# Altium schematic sheet Circuit Schematics.SchDoc("Circuit Schematics")
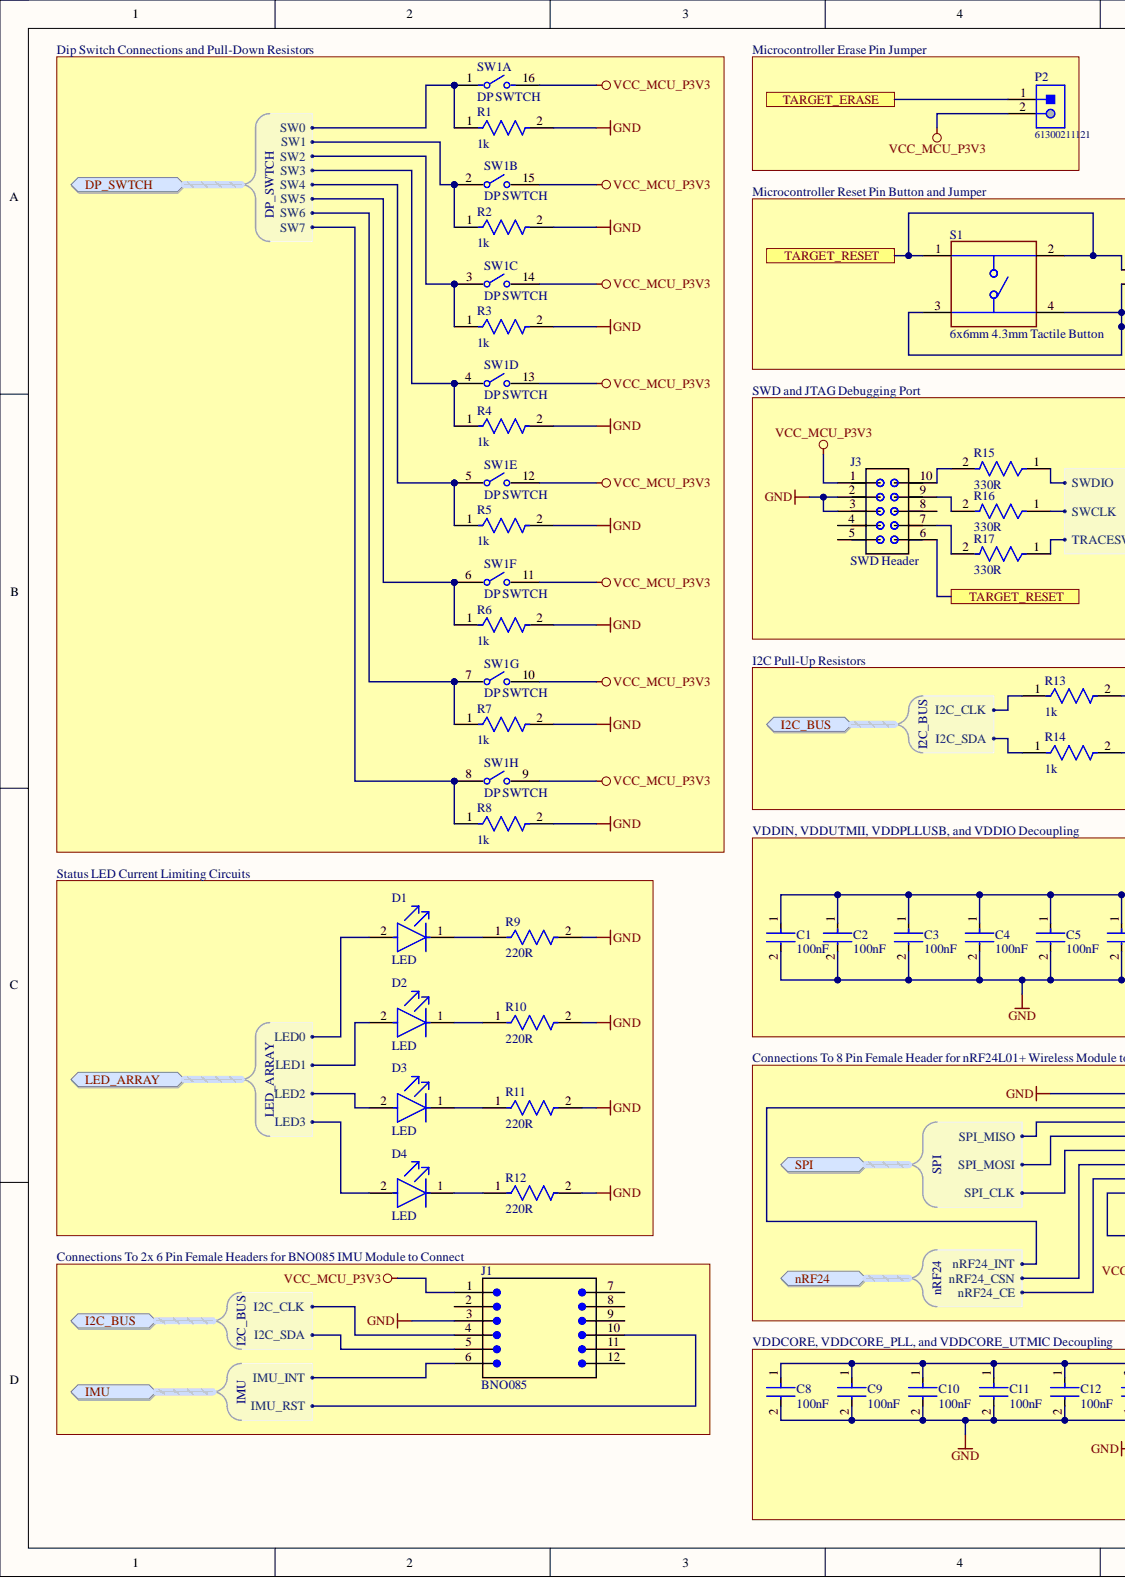
[diagram p0: Circuit Schematics.SchDoc, left]
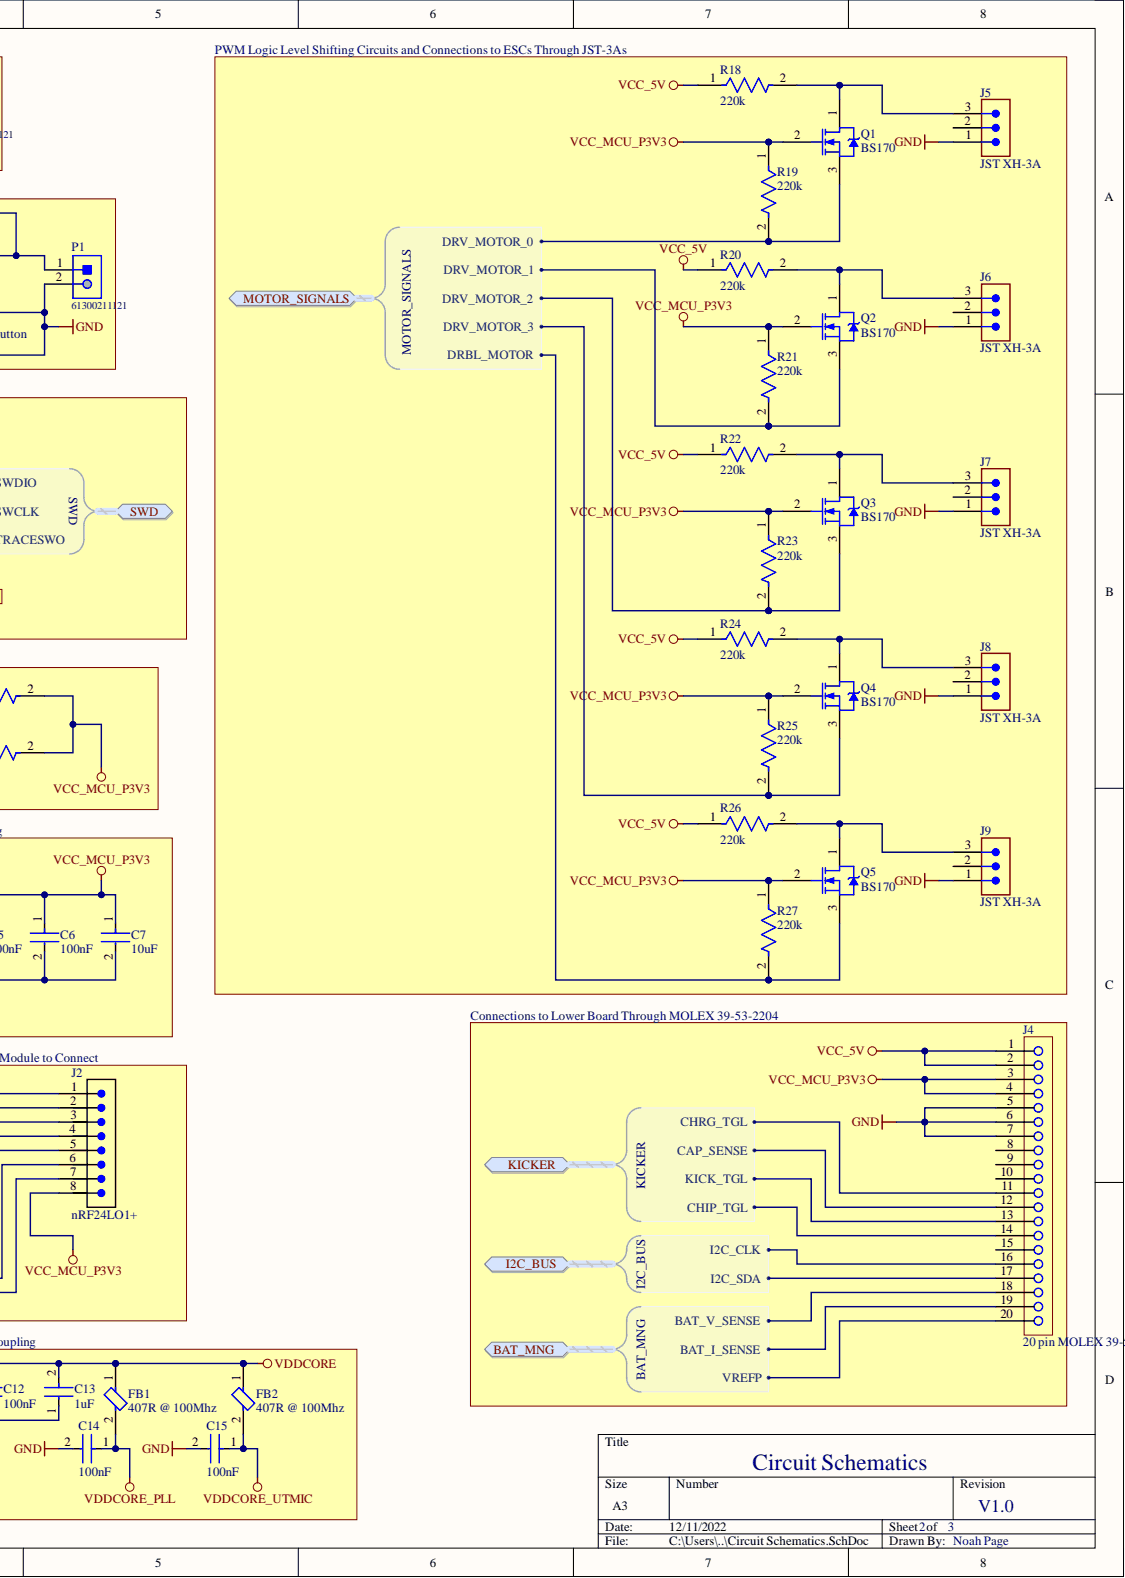
[diagram p1: Circuit Schematics.SchDoc, right]

## Parts
C1  100nF  [Capacitor 100nF 50V 20% 0603]
C2  100nF  [Capacitor 100nF 50V 20% 0603]
C3  100nF  [Capacitor 100nF 50V 20% 0603]
C4  100nF  [Capacitor 100nF 50V 20% 0603]
C5  100nF  [Capacitor 100nF 50V 20% 0603]
C6  100nF  [Capacitor 100nF 50V 20% 0603]
C7  10uF  [Capacitor 10uF 50V 20% 0805]
C8  100nF  [Capacitor 100nF 50V 20% 0603]
C9  100nF  [Capacitor 100nF 50V 20% 0603]
C10  100nF  [Capacitor 100nF 50V 20% 0603]
C11  100nF  [Capacitor 100nF 50V 20% 0603]
C12  100nF  [Capacitor 100nF 50V 20% 0603]
C13  1uF  [Capacitor 1uF 50V 10% 0603]
C14  100nF  [Capacitor 100nF 50V 20% 0603]
C15  100nF  [Capacitor 100nF 50V 20% 0603]
D1  LED  [LED RED 1206]
D2  LED  [LED RED 1206]
D3  LED  [LED RED 1206]
D4  LED  [LED RED 1206]
FB1  407R @ 100Mhz  [FERRITE BEAD 470R 100Mhz]
FB2  407R @ 100Mhz  [FERRITE BEAD 470R 100Mhz]
J1  BNO085  [(BNO085) FEMALE 2ct 8 unit separation 1x6 THT]
J2  nRF24LO1+  [(nRF24) FEMALE 1x8 2.54]
J3  SWD Header  [(SWD) FEMALE 10 pin 2 row 1.27mm]
J4  20 pin MOLEX 39-53-2204  [20Pin Molex 39-53-2204]  (value not drawn)
J5  JST XH-3A  [FP-B3B-XH-AM_LF_SN-MFG]
J6  JST XH-3A  [FP-B3B-XH-AM_LF_SN-MFG]
J7  JST XH-3A  [FP-B3B-XH-AM_LF_SN-MFG]
J8  JST XH-3A  [FP-B3B-XH-AM_LF_SN-MFG]
J9  JST XH-3A  [FP-B3B-XH-AM_LF_SN-MFG]
P1  61300211121  [2x 2.54mm MALE header pin]
P2  61300211121  [2x 2.54mm MALE header pin]
Q1  BS170  [MOSFET BS170]
Q2  BS170  [MOSFET BS170]
Q3  BS170  [MOSFET BS170]
Q4  BS170  [MOSFET BS170]
Q5  BS170  [MOSFET BS170]
R1  1k  [RESISTOR 1k 1% 1/4W 0603]
R2  1k  [RESISTOR 1k 1% 1/4W 0603]
R3  1k  [RESISTOR 1k 1% 1/4W 0603]
R4  1k  [RESISTOR 1k 1% 1/4W 0603]
R5  1k  [RESISTOR 1k 1% 1/4W 0603]
R6  1k  [RESISTOR 1k 1% 1/4W 0603]
R7  1k  [RESISTOR 1k 1% 1/4W 0603]
R8  1k  [RESISTOR 1k 1% 1/4W 0603]
R9  220R  [RESISTOR 220R 5% 1/4W 0603]
R10  220R  [RESISTOR 220R 5% 1/4W 0603]
R11  220R  [RESISTOR 220R 5% 1/4W 0603]
R12  220R  [RESISTOR 220R 5% 1/4W 0603]
R13  1k  [RESISTOR 1k 1% 1/4W 0603]
R14  1k  [RESISTOR 1k 1% 1/4W 0603]
R15  330R  [RESISTOR 330R 5% 1/4W 0603]
R16  330R  [RESISTOR 330R 5% 1/4W 0603]
R17  330R  [RESISTOR 330R 5% 1/4W 0603]
R18  220k  [RESISTOR 220k 5% 1/4W 0603]
R19  220k  [RESISTOR 220k 5% 1/4W 0603]
R20  220k  [RESISTOR 220k 5% 1/4W 0603]
R21  220k  [RESISTOR 220k 5% 1/4W 0603]
R22  220k  [RESISTOR 220k 5% 1/4W 0603]
R23  220k  [RESISTOR 220k 5% 1/4W 0603]
R24  220k  [RESISTOR 220k 5% 1/4W 0603]
R25  220k  [RESISTOR 220k 5% 1/4W 0603]
R26  220k  [RESISTOR 220k 5% 1/4W 0603]
R27  220k  [RESISTOR 220k 5% 1/4W 0603]
S1  6x6mm 4.3mm Tactile Button  [BUTTON 6x6x4.3 TACTILE SWITCH]
SW1  DP SWTCH  [8P DP SWTCH]  (parts A,B,C,D,E,F,G,H)

## Ports
BAT_MNG, BAT_MNG.BAT_I_SENSE, BAT_MNG.BAT_V_SENSE, BAT_MNG.VREFP, DP_SWTCH, DP_SWTCH.SW0, DP_SWTCH.SW1, DP_SWTCH.SW2, DP_SWTCH.SW3, DP_SWTCH.SW4, DP_SWTCH.SW5, DP_SWTCH.SW6, DP_SWTCH.SW7, I2C_BUS, I2C_BUS.I2C_CLK, I2C_BUS.I2C_SDA, IMU, IMU.IMU_INT, IMU.IMU_RST, KICKER, KICKER.CAP_SENSE, KICKER.CHIP_TGL, KICKER.CHRG_TGL, KICKER.KICK_TGL, LED_ARRAY, LED_ARRAY.LED0, LED_ARRAY.LED1, LED_ARRAY.LED2, LED_ARRAY.LED3, MOTOR_SIGNALS, MOTOR_SIGNALS.DRBL_MOTOR, MOTOR_SIGNALS.DRV_MOTOR_0, MOTOR_SIGNALS.DRV_MOTOR_1, MOTOR_SIGNALS.DRV_MOTOR_2, MOTOR_SIGNALS.DRV_MOTOR_3, nRF24, nRF24.nRF24_CE, nRF24.nRF24_CSN, nRF24.nRF24_INT, SPI, SPI.SPI_CLK, SPI.SPI_MISO, SPI.SPI_MOSI, SWD, SWD.SWCLK, SWD.SWDIO, SWD.TRACESWO, TARGET_ERASE, TARGET_RESET

## Nets
GND: C1.2 C2.2 C3.2 C4.2 C5.2 C6.2 C7.2 C8.2 C9.2 C10.2 C11.2 C12.2 C13.1 C14.2 C15.2 J1.3 J2.1 J3.2 J3.3 J4.5 J4.6 J4.7 J5.1 J6.1 J7.1 J8.1 J9.1 P1.2 R1.2 R2.2 R3.2 R4.2 R5.2 R6.2 R7.2 R8.2 R9.2 R10.2 R11.2 R12.2 S1.3 S1.4
VCC_5V: J4.1 J4.2 R18.1 R20.1 R22.1 R24.1 R26.1
VCC_MCU_P3V3: C1.1 C2.1 C3.1 C4.1 C5.1 C6.1 C7.1 J1.1 J2.8 J3.1 J4.3 J4.4 P2.2 Q1.2 Q2.2 Q3.2 Q4.2 Q5.2 R13.2 R14.2 R19.1 R21.1 R23.1 R25.1 R27.1 SW1.9 SW1.10 SW1.11 SW1.12 SW1.13 SW1.14 SW1.15 SW1.16
VDDCORE: C8.1 C9.1 C10.1 C11.1 C12.1 C13.2 FB1.1 FB2.1
VDDCORE_PLL: C14.1 FB1.2
VDDCORE_UTMIC: C15.1 FB2.2
TARGET_ERASE: P2.1 ; port TARGET_ERASE
TARGET_RESET: J3.6 P1.1 S1.1 S1.2 ; port TARGET_RESET
NetD1_1: D1.1 R9.1
NetD1_2: D1.2 ; port LED_ARRAY
NetD2_1: D2.1 R10.1
NetD2_2: D2.2 ; port LED_ARRAY
NetD3_1: D3.1 R11.1
NetD3_2: D3.2 ; port LED_ARRAY
NetD4_1: D4.1 R12.1
NetD4_2: D4.2 ; port LED_ARRAY
NetJ1_4: J1.4 J4.16 R13.1 ; port I2C_BUS
NetJ1_5: J1.5 J4.17 R14.1 ; port I2C_BUS
NetJ1_6: J1.6 ; port IMU
NetJ1_10: J1.10 ; port IMU
NetJ2_2: J2.2 ; port nRF24
NetJ2_3: J2.3 ; port SPI
NetJ2_4: J2.4 ; port SPI
NetJ2_5: J2.5 ; port SPI
NetJ2_6: J2.6 ; port nRF24
NetJ2_7: J2.7 ; port nRF24
NetJ3_7: J3.7 R17.2
NetJ3_9: J3.9 R16.2
NetJ3_10: J3.10 R15.2
NetJ4_11: J4.11 ; port KICKER
NetJ4_12: J4.12 ; port KICKER
NetJ4_13: J4.13 ; port KICKER
NetJ4_14: J4.14 ; port KICKER
NetJ4_18: J4.18 ; port BAT_MNG
NetJ4_19: J4.19 ; port BAT_MNG
NetJ4_20: J4.20 ; port BAT_MNG
NetJ5_3: J5.3 Q1.1 R18.2
NetJ6_3: J6.3 Q2.1 R20.2
NetJ7_3: J7.3 Q3.1 R22.2
NetJ8_3: J8.3 Q4.1 R24.2
NetJ9_3: J9.3 Q5.1 R26.2
NetQ1_3: Q1.3 R19.2 ; port MOTOR_SIGNALS
NetQ2_3: Q2.3 R21.2 ; port MOTOR_SIGNALS
NetQ3_3: Q3.3 R23.2 ; port MOTOR_SIGNALS
NetQ4_3: Q4.3 R25.2 ; port MOTOR_SIGNALS
NetQ5_3: Q5.3 R27.2 ; port MOTOR_SIGNALS
NetR1_1: R1.1 SW1.1 ; port DP_SWTCH
NetR2_1: R2.1 SW1.2 ; port DP_SWTCH
NetR3_1: R3.1 SW1.3 ; port DP_SWTCH
NetR4_1: R4.1 SW1.4 ; port DP_SWTCH
NetR5_1: R5.1 SW1.5 ; port DP_SWTCH
NetR6_1: R6.1 SW1.6 ; port DP_SWTCH
NetR7_1: R7.1 SW1.7 ; port DP_SWTCH
NetR8_1: R8.1 SW1.8 ; port DP_SWTCH
NetR15_1: R15.1 ; port SWD
NetR16_1: R16.1 ; port SWD
NetR17_1: R17.1 ; port SWD

Not connected: J1 2 7 8 9 11 12; J3 4 5 8; J4 8 9 10 15; J5 2; J6 2; J7 2; J8 2; J9 2

## Library data of the parts
C1-C6 C8-C12 C14 C15 = Capacitor 100nF 50V 20% 0603: Cap Ceramic 100nF 50V X7R ±20% Pad SMD 0603 +125°C T/R; Capacitance: 100nF; Case Code (Imperial): 0603; Case Code (Metric): 1608; Case/Package: 0603; Component Kind: Standard; Depth: 0.8mm; Dielectric: X7R; Height: 0.8mm; Lead Free: Lead Free; Length: 1.6mm; Library Name: Schematic Library.SchLib; Max Operating Temperature: 125°C; Min Operating Temperature: -55°C; Mount: Surface Mount; Package Quantity: 1; Packaging: Tape and Reel; Radiation Hardening: No; Thickness: 0.035inch; Tolerance: 20%; Voltage Rating: 50V; Voltage Rating (DC): 50V; Width: 0.031inch
C7 = Capacitor 10uF 50V 20% 0805: Chip Multilayer Ceramic Capacitors for General Purpose, 0805, 10uF, X5R, 15%, 20%, 50V; Component Kind: Standard; Library Name: Schematic Library.SchLib
C13 = Capacitor 1uF 50V 10% 0603: Multilayer Ceramic Capacitors 1μF ±10% 50V X5R SMD 0603; Capacitance: 1uF; Case Code (Imperial): 0603; Case Code (Metric): 1608; Case/Package: 0603; Component Kind: Standard; Construction: Flat; Depth: 0.8mm; Dielectric: X5R; Dielectric Material: Ceramic; Height: 0.8mm; Lead Free: Lead Free; Length: 1.6mm; Library Name: Schematic Library.SchLib; Max Operating Temperature: 85°C; Min Operating Temperature: -55°C; Mount: Surface Mount; Number of Pins: 2; Package Quantity: 1; Packaging: Tape and Reel; Radiation Hardening: No; REACH SVHC: No SVHC; Resistor Type: Ceramic; RoHS Compliant: Yes; Series: C; Termination: SMD/SMT; Thickness: 0.035inch; Tolerance: 10%; Voltage: 50V; Voltage Rating: 50V; Voltage Rating (DC): 50V; Weight: 7.1E-05oz; Width: 0.031inch
D1-D4 = LED RED 1206: LED RED DIFFUSED 1206 SMD; Manufacturer: VCC; Manufacturer Part Number: CMD15-21VRD/TR8; Forward Current: 20mA; Forward Voltage: 2V; Led Orientation: Top View; Luminous Intensity: 4mcd; Peak Emmision Wavelength: 640nm; Power Dissipation: 105mW; Reverse Voltage: 5V; Automotive: No; Category: Diode; Colour: Red; Component Kind: Standard; ComponentLink2Description: Datasheet; ComponentLink2URL: https://www.vcclite.com/wp-content/uploads/wpallimport/files/files/CMD1521SeriesRev1.pdf; Device Class L1: Optoelectronics; Device Class L2: LEDs; Device Class L3: unset; Digikey Description: LED RED DIFFUSED 1206 SMD; Height: 1.3mm; Lead Free: Yes; Library Name: Schematic Library.SchLib; Mouser Description: Standard LEDs - SMD RED DIFFUSED; Octopart: https://octopart.com/cmd15-21vrd%2Ftr8-vcc-26668835; Package: CMD15-21; RoHS: TRUE; Temperature: -40°C to +85°C; Temperature Range High: +85°C; Temperature Range Low: -40°C
FB1 FB2 = Ferrite Bead 470R @ 100Mhz 25%: Chip Ferrite Bead, 0603, 470Ω @ 100MHz, 0.35Ω, 25%, 550mA; DC Resistance (DCR): 350mR; Impedance: 470R; Max DC Current: 500mA; Number of Circuits: 1; Shielding: Unshielded; Case Code (Imperial): 0603; Case Code (Metric): 1608; Case/Package: 0603; Component Kind: Standard; Contact Plating: Tin; Core Material: Ferrite; Depth: 0.8mm; Height: 0.037inch; Lead Free: Lead Free; Length: 1.6mm; Library Name: Schematic Library.SchLib; Max Current Rating: 550mA; Max Operating Temperature: 125°C; Min Operating Temperature: -55°C; Mount: Surface Mount; Number of Lines: 1; Number of Pins: 2; Package Quantity: 4000; Packaging: Tape and Reel; Radiation Hardening: No; Ratings: AEC-Q200; REACH SVHC: No SVHC; Resistance: 350mR; Termination: Flat; Test Frequency: 100MHz; Tolerance: 25%; Weight: 7.1E-05oz; Width: 0.031inch
J1 = (BNO085) FEMALE 2ct 8 unit separation 1x6 THT: No Description Available; Manufacturer_Part_Number: PPTC061LFBN-RC; Mfr_Name: Sullins; Component Kind: Standard; Digi-Key_Part_Number_1: S7004-ND; Library Name: Schematic Library.SchLib; RefDes: RefDes; Type: DEV; Copyright: Copyright (C) 2022 Ultra Librarian. All rights reserved.
J2 = (nRF24) FEMALE 1x8 2.54: No Description Available; Manufacturer_Part_Number: PPTC081LFBN-RC; Mfr_Name: Sullins; Component Kind: Standard; Digi-Key_Part_Number_1: S7006-ND; Digi-Key_Part_Number_2: S7006-NDL; Library Name: Schematic Library.SchLib; RefDes: RefDes; Type: DEV; Copyright: Copyright (C) 2022 Ultra Librarian. All rights reserved.
J3 = (SWD) FEMALE 10 pin 2 row 1.27mm: No Description Available; Manufacturer_Part_Number: 3220-10-0100-00; Mfr_Name: CNC Tech; Component Kind: Standard; Digi-Key_Part_Number_1: 1175-1627-ND; Library Name: Schematic Library.SchLib; RefDes: RefDes; Type: DEV; Copyright: Copyright (C) 2022 Ultra Librarian. All rights reserved.
J4 = 20 pin MOLEX 39-53-2204: 1.25 mm Pitch FPC Connector, 5.0 mm Mated Height, Right Angle, ZIF, Through Hole, Top Contact, 20 Circuits, -20 to 80 degC, ELV and RoHS Compliant, Tray; Circuits (Loaded): 20; Current (Max per Contact): 1A; Durability (mating cycles max): 30; Entry Angle: 90degrees Angle; Mated Height: 5.00mm; Material - Metal: Phosphor Bronze; Material - Plating Mating: Tin-Bismuth; PCB Locator: No; PCB Retention: None; Pitch - Mating Interface: 1.25mm; Voltage (Max): 200V; Component Kind: Standard; ComponentLink1Description: Manufacturer URL; ComponentLink1URL: http://www.molex.com/molex/index.jsp; ComponentLink2Description: Datasheet; ComponentLink2URL: http://www.molex.com/webdocs/datasheets/pdf/en-us/0039532204_FFC_FPC_CONNECTORS.pdf; ComponentLink3Description: Package Specification; ComponentLink3URL: http://www.molex.com/pdm_docs/sd/039532204_sd.pdf; Contact Position: Top; Library Name: Schematic Library.SchLib; Manufacturer: Molex; Material - Plating Termination: Tin-Bismuth; Mounting Technology: Through Hole; Number of Rows: 1; Orientation: Right Angle; PackageDescription: 20-Lead FPC Connector, Pitch 1.25 mm; PackageReference: 39-53-2204; PackageVersion: Rev. B, 04/2007; Packing: Tray; PartNumber: 39-53-2204; Polarized to PCB: No; RoHS: TRUE; Stackable: No
J5-J9 = 3pin JSTHX MALE: CONN HEADER VERT 3POS 2.5MM; Connector Type: Male Pin; Contact Resistance: 20mR; Gender: Male; Max Current Rating: 3A; Max Voltage Rating (AC): 250V; Number of Positions: 3; Pitch: 0.098inch; Color: Natural; Component Kind: Standard; Contact Material: Brass; Contact Plating: Tin; Contact Type: Male Pin; Depth: 5.75mm; Fastening Type: Detent; Housing Color: White; Housing Material: Polyamide; Lead Free: Lead Free; Length: 9.9mm; Library Name: Schematic Library.SchLib; Max Operating Temperature: 85°C; Min Operating Temperature: -25°C; Mount: Through Hole; Number of Rows: 1; Orientation: Straight; Packaging: Bulk; Series: XH; Termination: Press-Fit
P1 P2 = 2x 2.54mm Male Header: THT Vertical Pin Header WR-PHD, Pitch 2.54 mm, Single Row, 2 pins; Manufacturer: Wurth Elektronik; Contact Resistance: 20mOhm; Gender: Male; Pin Number: 2; Pitch: 2.54mm; Rated Current: 3A; Withstanding Voltage: 500VAC; Working Voltage: 250VAC; Component Kind: Standard; Datasheet URL: https://katalog.we-online.de/em/datasheet/6130xx11121.pdf; Library Name: Schematic Library.SchLib; Manufacturer URL: http://www.we-online.de; Mounting Technology: THT; Operating Temperature: -40to105degC; Orientation: Vertical; Package Reference: 61300211121; Packaging: Bag; Part Number: 61300211121; Row Number: Single; Publisher: WurthElektronik
Q1-Q5 = MOSFET Transistor NChannel Logic Level BS170: Small Signal MOSFET, 500 mA, 60 V, N-Channel, 3-Pin TO-92, Bulk Box; Manufacturer: On Semiconductor; Configuration: Single; ID Max (A): 0.5; PD Max (W): 0.35; V(BR)DSS Min (V): 60; VGS Max (V): 20; VGS(th) Max (V): 3; Channel Polarity: N Channel; Component Kind: Standard; ComponentLink1Description: Manufacturer URL; ComponentLink1URL: http://www.onsemi.com/; ComponentLink2Description: Datasheet; ComponentLink2URL: http://www.onsemi.com/pub_link/Collateral/BS170-D.PDF; ComponentLink3Description: Package Specification; ComponentLink3URL: http://www.onsemi.cn/pub_link/Collateral/2N6426-D.PDF; DatasheetVersion: Rev. 6; Library Name: Schematic Library.SchLib; Mounting Technology: Through Hole; Package Type: TO-92; PackageDescription: 3-Pin Transistor Outline, Body 4.825 x 3.685 mm, Pitch 1.27 mm; PackageReference: TO-92-3-29-11_AM_SL; PackageVersion: Rev. AM; Packing: Bulk Box; PartNumber: BS170
R1-R8 R13 R14 = Resistor 1k 1% 0.25W 0603: 1kΩ ±1% 0.25W 0603 Anti-Surge Chip Resistor AEC-Q200; Max Power Dissipation: 250mW; Resistance: 1kR; Temperature Coefficient: 100ppm/°C; Case Code (Imperial): 0603; Case Code (Metric): 1608; Case/Package: 0603; Component Kind: Standard; Features: Automotive AEC-Q200; Height: 0.022inch; Lead Free: Lead Free; Length: 0.063inch; Library Name: Schematic Library.SchLib; Max Operating Temperature: 155°C; Min Operating Temperature: -55°C; Number of Terminations: 2; Package Quantity: 1; Packaging: Tape and Reel; Power Rating: 250mW; Resistor Type: Thick Film; RoHS Compliant: Yes; Series: ESR; Size Code: 0603; Tolerance: 1%; Width: 0.031inch
R9-R12 = Resistor 220R 5% 0.25W 0603: 220Ω ±5% 0.25W 0603 Anti-Surge Chip Resistor AEC-Q200; Max Power Dissipation: 250mW; Temperature Coefficient: 200ppm/°C; Case Code (Imperial): 0603; Case Code (Metric): 1608; Case/Package: 0603; Component Kind: Standard; Features: Automotive AEC-Q200; Height: 0.022inch; Lead Free: Lead Free; Length: 0.063inch; Library Name: Schematic Library.SchLib; Max Operating Temperature: 155°C; Min Operating Temperature: -55°C; Number of Terminations: 2; Package Quantity: 1; Packaging: Tape and Reel; Resistance: 220R; Resistor Type: Thick Film; RoHS Compliant: Yes; Series: ESR; Tolerance: 5%; Width: 0.031inch
R15-R17 = Resistor 330R 5% 0.25W 0603: 330Ω ±5% 0.25W 0603 Anti-Surge Chip Resistor AEC-Q200; Max Power Dissipation: 250mW; Temperature Coefficient: 200ppm/°C; Case Code (Imperial): 0603; Case Code (Metric): 1608; Case/Package: 0603; Component Kind: Standard; Features: Automotive AEC-Q200; Height: 0.45mm; Length: 1.6mm; Library Name: Schematic Library.SchLib; Max Operating Temperature: 155°C; Min Operating Temperature: -55°C; Number of Terminations: 2; Packaging: Tape and Reel; Resistance: 330R; RoHS Compliant: Yes; Series: ESR; Tolerance: 5%; Width: 0.8mm
R18-R27 = Resistor 220k 5% 0.25W 0603: 220kΩ ±5% 0.25W 0603 Anti-Surge Chip Resistor AEC-Q200; Max Power Dissipation: 250mW; Resistance: 220kR; Temperature Coefficient: 200ppm/°C; Case Code (Imperial): 0603; Case Code (Metric): 1608; Case/Package: 0603; Component Kind: Standard; Features: Automotive AEC-Q200; Height: 0.022inch; Length: 0.063inch; Library Name: Schematic Library.SchLib; Max Operating Temperature: 155°C; Min Operating Temperature: -55°C; Number of Terminations: 2; Package Quantity: 5000; Packaging: Tape and Reel; Resistor Type: Thick Film; RoHS Compliant: Yes; Series: ESR; Tolerance: 5%; Width: 0.031inch
S1 = 6x6mm 4.3mm Tactile Button: 6 x 6 mm, 4.3 mm Actuator Height, 160 gf, Black, Long Crimped, Through Hole, SPST, Tactile Switch; MF: CUI Devices; MP: TS02-66-43-BK-160-LCR-D; Availability: In Stock; Check_prices: https://www.snapeda.com/parts/TS02-66-43-BK-160-LCR-D/CUI+Devices/view-part/?ref=eda; Component Kind: Standard; CUI_purchase_URL: https://www.cuidevices.com/product/switches/tactile-switches/ts02-series?utm_source=snapeda.com&utm_medium=referral&utm_campaign=snapedaBOM; Library Name: Schematic Library.SchLib; Package: None; Price: None; Purchase-URL: https://pricing.snapeda.com/search/part/TS02-66-43-BK-160-LCR-D/?ref=eda; SnapEDA_Link: https://www.snapeda.com/parts/TS02-66-43-BK-160-LCR-D/CUI+Devices/view-part/?ref=snap
SW1 = 8Pin 20V 100mA Dip Switch: SWITCH SLIDE DIP SPST 100MA 20V; Actuator Type: Slide (Standard); Electrical Life: 2000Cycles; Number of Positions: 8; Pitch: 0.1inch; Washable: Yes; Component Kind: Standard; Contact Material: Copper; Contact Plating: Gold; Current Rating: 100mA; Depth: 9.91mm; Lead Free: Lead Free; Length: 0.858inch; Library Name: Schematic Library.SchLib; Max Current Rating: 100mA; Max Operating Temperature: 85°C; Max Voltage Rating (DC): 20V; Mechanical Life: 2000Cycles; Min Operating Temperature: -55°C; Mount: Surface Mount; Orientation: Straight; Package Quantity: 1000; Packaging: Tape and Reel; Radiation Hardening: No; Termination: Gull Wing; Throw Configuration: SPST; Voltage Rating (DC): 20V
